# Altium schematic sheet PWR0.SchDoc(PWR0)
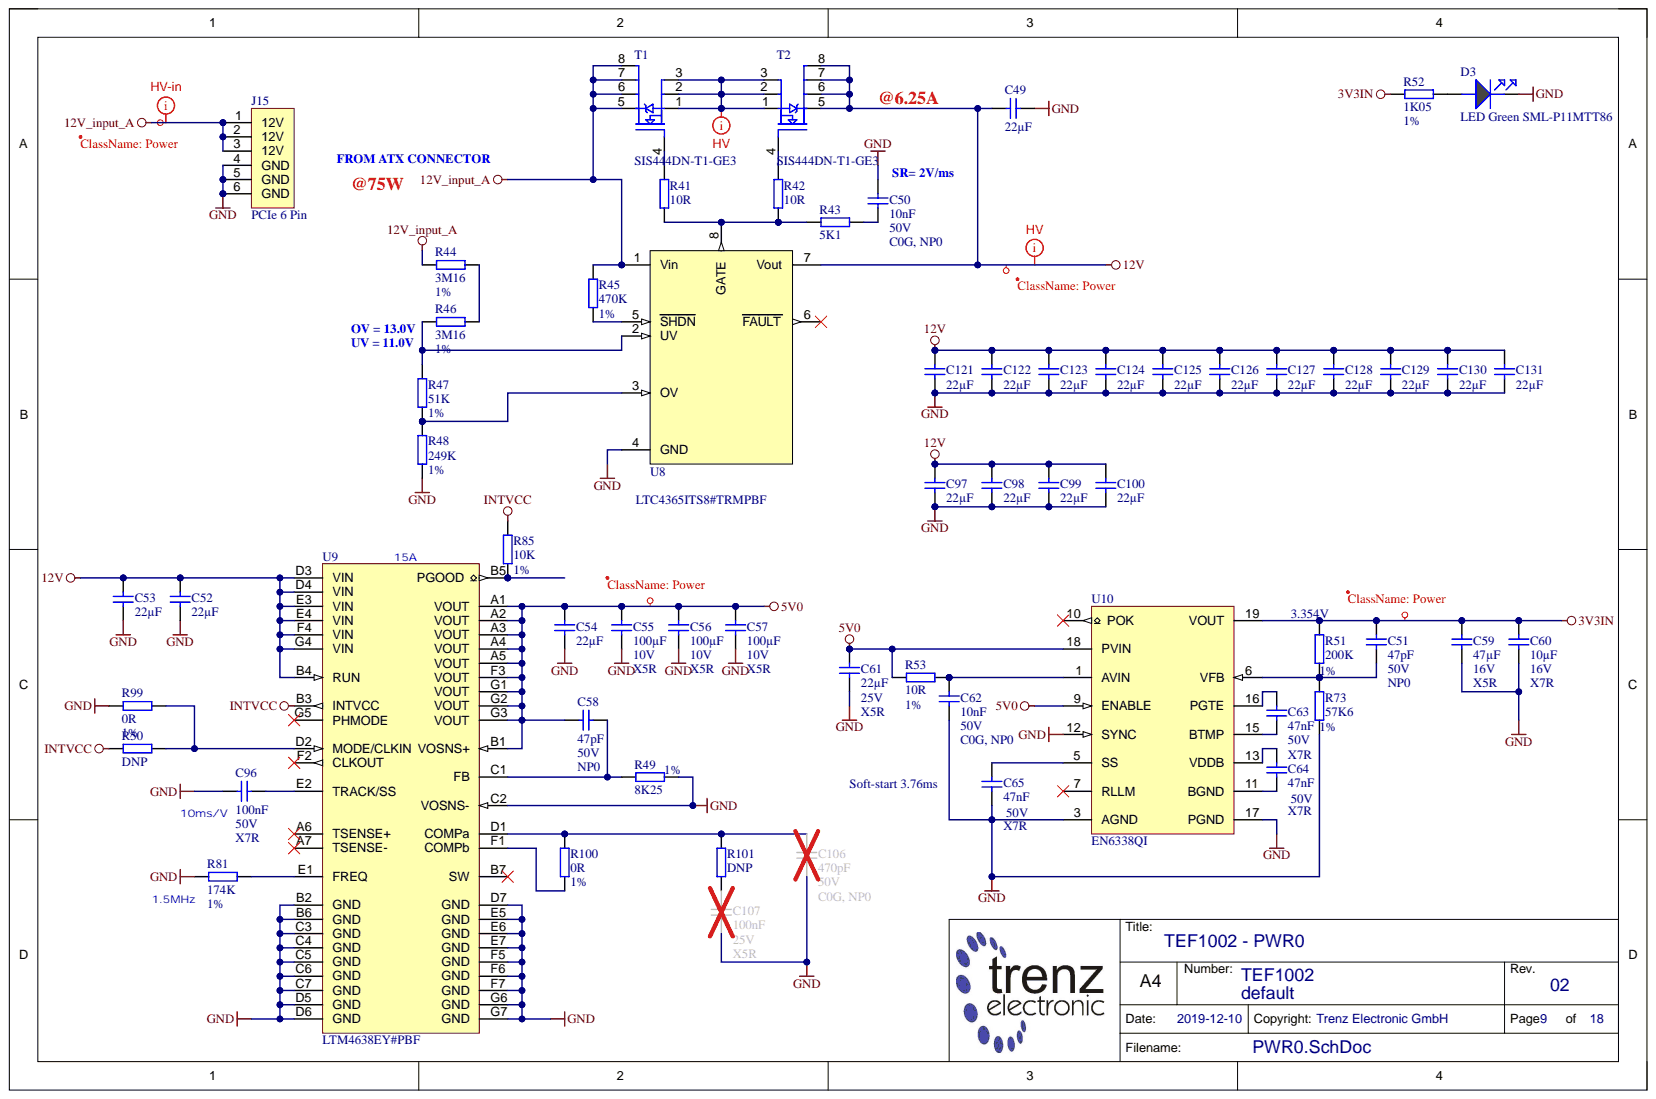
[diagram p0: PWR0.SchDoc]

## Parts
C49  22µF  [CAPC3216X180N]
C50  10nF  Voltage: 50V  [CAPC1608X90N]
C51  47pF  Technology: NP0  Voltage: 50V  [CAPC1005X55N]
C52  22µF  [CAPC3216X180N]
C53  22µF  [CAPC3216X180N]
C54  22µF  [CAPC3216X180N]
C55  100µF  Technology: X5R  Voltage: 10V  [CAPC3216X180N]
C56  100µF  Technology: X5R  Voltage: 10V  [CAPC3216X180N]
C57  100µF  Technology: X5R  Voltage: 10V  [CAPC3216X180N]
C58  47pF  Voltage: 50V  [CAPC1005X55N]
C59  47µF  Technology: X5R  Voltage: 16V  [CAPC3216X180N]
C60  10µF  Technology: X7R  Voltage: 16V  [CAPC2012X135N]
C61  22µF  Technology: X5R  Voltage: 25V  [CAPC3216X180N]
C62  10nF  Voltage: 50V  [CAPC1608X90N]
C63  47nF  Voltage: 50V  [CAPC1005X55N]
C64  47nF  Technology: X7R  Voltage: 50V  [CAPC1005X55N]
C65  47nF  Technology: X7R  Voltage: 50V  [CAPC1005X55N]
C96  100nF  Voltage: 50V  [CAPC1005X55N]
C97  22µF  [CAPC3216X180N]
C98  22µF  [CAPC3216X180N]
C99  22µF  [CAPC3216X180N]
C100  22µF  [CAPC3216X180N]
C106  470pF  Voltage: 50V  [CAPC1005X55N]
C107  100nF  Technology: X5R  Voltage: 25V  [CAPC1005X55N]
C121  22µF  [CAPC3216X180N]
C122  22µF  [CAPC3216X180N]
C123  22µF  [CAPC3216X180N]
C124  22µF  [CAPC3216X180N]
C125  22µF  [CAPC3216X180N]
C126  22µF  [CAPC3216X180N]
C127  22µF  [CAPC3216X180N]
C128  22µF  [CAPC3216X180N]
C129  22µF  [CAPC3216X180N]
C130  22µF  [CAPC3216X180N]
C131  22µF  [CAPC3216X180N]
D3  LED Green SML-P11MTT86  Database Table Name: LED  H-ART: SML-P11MTT86  [LEDC1006X25N_GREEN]
J15  PCIe 6 Pin  [45558-0003]
R41  10R  [RESC1005X40N]
R42  10R  [RESC1005X40N]
R43  5K1  [RESC1005X40N]
R44  3M16  [RESC1005X40N]
R45  470K  Tolerance: 1%  [RESC1005X40N]
R46  3M16  Tolerance: 1%  [RESC1005X40N]
R47  51K  Tolerance: 1%  [RESC1005X40N]
R48  249K  Tolerance: 1%  [RESC1005X40N]
R49  8K25  Tolerance: 1%  [RESC1005X40N]
R50  DNP  [RESC1005X40N]  (no BOM)
R51  200K  Tolerance: 1%  [RESC1005X40N]
R52  1K05  Tolerance: 1%  [RESC1005X40N]
R53  10R  Tolerance: 1%  [RESC1005X40N]
R73  57K6  Tolerance: 1%  [RESC1005X40N]
R81  174K  Tolerance: 1%  [RESC1005X40N]
R85  10K  Tolerance: 1%  [RESC1005X40N]
R99  0R  [RESC1005X40N]
R100  0R  Tolerance: 1%  [RESC1005X40N]
R101  DNP  [RESC1005X40N]  (no BOM)
T1  SIS444DN-T1-GE3  [Si7106]
T2  SIS444DN-T1-GE3  [Si7106]
U8  LTC4365ITS8#TRMPBF  [TSOT-23-8]
U9  LTM4638EY#PBF  [BGA49C80P7X7_625X625X536B50N]
U10  EN6338QI  [EN6338QI]

## Nets
3V3IN: C51.1 C59.1 C60.2 R51.1 R52.1 U10.19(VOUT)
5V0: C54.2 C55.1 C56.1 C57.1 C58.1 C61.1 R53.1 U9.A1(VOUT) U9.A2(VOUT) U9.A3(VOUT) U9.A4(VOUT) U9.A5(VOUT) U9.B1(VOSNS+) U9.F3(VOUT) U9.G1(VOUT) U9.G2(VOUT) U9.G3(VOUT) U10.9(ENABLE) U10.18(PVIN)
12V: C49.2 C52.2 C53.2 C97.2 C98.2 C99.2 C100.2 C121.2 C122.2 C123.2 C124.2 C125.2 C126.2 C127.2 C128.2 C129.2 C130.2 C131.2 T2.5 T2.6 T2.7 T2.8 U8.7(Vout) U9.B4(RUN) U9.D3(VIN) U9.D4(VIN) U9.E3(VIN) U9.E4(VIN) U9.F4(VIN) U9.G4(VIN)
12V_input_A: J15.1(12V) J15.2(12V) J15.3(12V) R44.1 R45.1 T1.5 T1.6 T1.7 T1.8 U8.1(Vin)
GND: C49.1 C50.1 C52.1 C53.1 C54.1 C55.2 C56.2 C57.2 C59.2 C60.1 C61.2 C62.2 C65.2 C96.2 C97.1 C98.1 C99.1 C100.1 C106.1 C107.2 C121.1 C122.1 C123.1 C124.1 C125.1 C126.1 C127.1 C128.1 C129.1 C130.1 C131.1 D3.K J15.4(GND) J15.5(GND) J15.6(GND) R48.2 R49.2 R73.2 R81.1 R99.1 U8.4(GND) U9.B2(GND) U9.B6(GND) U9.C2(VOSNS-) U9.C3(GND) U9.C4(GND) U9.C5(GND) U9.C6(GND) U9.C7(GND) U9.D5(GND) U9.D6(GND) U9.D7(GND) U9.E5(GND) U9.E6(GND) U9.E7(GND) U9.F5(GND) U9.F6(GND) U9.F7(GND) U9.G6(GND) U9.G7(GND) U10.3(AGND) U10.12(SYNC) U10.17(PGND)
INTVCC: R50.1 R85.2 U9.B3(INTVCC)
NetC50_2: C50.2 R43.2
NetC51_2: C51.2 R51.2 R73.1 U10.6(VFB)
NetC58_2: C58.2 R49.1 U9.C1(FB)
NetC62_1: C62.1 R53.2 U10.1(AVIN)
NetC63_1: C63.1 U10.16(PGTE)
NetC63_2: C63.2 U10.15(BTMP)
NetC64_1: C64.1 U10.13(VDDB)
NetC64_2: C64.2 U10.11(BGND)
NetC65_1: C65.1 U10.5(SS)
NetC96_1: C96.1 U9.E2(TRACK/SS)
NetC106_2: C106.2 R100.2 R101.2 U9.D1(COMPa)
NetC107_1: C107.1 R101.1
NetD3_A: D3.A R52.2
NetR41_1: R41.1 T1.4
NetR41_2: R41.2 R42.2 R43.1 U8.8(GATE)
NetR42_1: R42.1 T2.4
NetR44_2: R44.2 R46.2
NetR45_2: R45.2 U8.5(SHDN)
NetR46_1: R46.1 R47.1 U8.2(UV)
NetR47_2: R47.2 R48.1 U8.3(OV)
NetR50_2: R50.2 R99.2 U9.D2(MODE/CLKIN)
NetR81_2: R81.2 U9.E1(FREQ)
NetR85_1: R85.1 U9.B5(PGOOD)
NetR100_1: R100.1 U9.F1(COMPb)
NetT1_1: T1.1 T1.2 T1.3 T2.1 T2.2 T2.3

Not connected: U8 6(FAULT); U9 A6(TSENSE+) A7(TSENSE-) B7(SW) F2(CLKOUT) G5(PHMODE); U10 7(RLLM) 10(POK)

## Library data of the parts
C49 C52-C54 C61 C97-C100 C121-C131 = 24886: KON 22µF X5R 25V 10%  SMD BF 3216 (1206); Database Table Name: C_3216_1206; Mixed: SMT; Preferred: Yes; Temperature-Range: -55/+85; Value: 22u; AN: 24886; Case: 3216 (1206); Component Kind: Standard; Library Name: TE.DbLib; Tolerance: 10%
  C49 C52-C54 C97-C100 C121-C131: Technology: X5R; Voltage: 25V
C50 C62 = 26587: KON 10nF 50V NP0 SMD BF 1608 (0603); Database Table Name: C_1608_0603; H-ART: C1608C0G1H103J080AA; Mixed: SMT; Value: 10n; AN: 26587; Case: 1608 (0603); Component Kind: Standard; Library Name: TE.DbLib; Technology: C0G, NP0
C51 C58 = 24885: KON 47pF 50V NP0 SMD BF 1005 (0402); Database Table Name: C_1005_0402; Mixed: SMT; Preferred: Yes; Value: 47p; AN: 24885; Case: 1005 (0402); Component Kind: Standard; Library Name: TE.DbLib
  C58: Technology: NP0
C55-C57 = 26278: KON 100µF 10V X5R 20% SMD BF 3216 (1206); Database Table Name: C_3216_1206; Mixed: SMT; Preferred: Yes; Temperature-Range: -55/+85; Value: 100u; AN: 26278; Case: 3216 (1206); Component Kind: Standard; Library Name: TE.DbLib; Tolerance: 20%
C59 = 24955: KON 47µF 16V  X5R 20% SMD BF 3216 (1206); Database Table Name: C_3216_1206; Mixed: SMT; Preferred: Yes; Temperature-Range: -55/+85; Value: 47u; AN: 24955; Case: 3216 (1206); Component Kind: Standard; Library Name: TE.DbLib; Tolerance: 20%
C60 = 24380: KON 10µF 16V X7R 10% SMD BF 2012 (0805); Database Table Name: C_2012_0805; Mixed: SMT; Preferred: Yes; Temperature-Range: -55/+125; Value: 10u; AN: 24380; Case: 2012 (0805); Component Kind: Standard; Library Name: TE.DbLib; Tolerance: 10%
C63-C65 = 24684: KON 47nF 50V X7R 10% SMD BF 1005 (0402); Database Table Name: C_1005_0402; Mixed: SMT; Temperature-Range: -55/+125; Value: 47n; AN: 24684; Case: 1005 (0402); Component Kind: Standard; Library Name: TE.DbLib; Tolerance: 10%
  C63: Technology: X7R
C96 = 22651: KON 100nF 50V X7R ±10% SMD BF 1005 (0402); Database Table Name: C_1005_0402; Mixed: SMT; Temperature-Range: -55/+125; Value: 100n; AN: 22651; Case: 1005 (0402); Component Kind: Standard; Library Name: TE.DbLib; Technology: X7R; Tolerance: 10%
C106 = 28221: KON 470pF 50V NP0 ±5% SMD BF 1005 (0402); Database Table Name: C_1005_0402; Mixed: SMT; Value: 470p; AN: 28221; Case: 1005 (0402); Component Kind: Standard; Library Name: TE.DbLib; Technology: C0G, NP0; Tolerance: ±5%
C107 = 22472: KON 100nF 25V X5R ±10% SMD BF 1005 (0402); Database Table Name: C_1005_0402; Mixed: SMT; Preferred: Yes; Temperature-Range: -55/+85; Value: 100n; AN: 22472; Case: 1005 (0402); Component Kind: Standard; Library Name: TE.DbLib
D3 = 24211: LED Grün SML-P11MTT86 SMD; Mixed: SMT; Preferred: Yes; Temperature-Range: -40/+85; AN: 24211; Case: 1005 (0402); Component Kind: Standard; Library Name: TE.DbLib; HelpURL: B:\AD\adlib\datasheet\SML-P1
J15 = 24680: Mini-Fit JR Header PCIe 6 Pin Header 90°; Database Table Name: CON_PWR; Mixed: THT; Trenz-Stock: Yes; AN: 24680; Component Kind: Standard; H-ART: 45558-0003; Library Name: TE.DbLib; HelpURL: B:\AD\adlib\datasheet\45558-0003
R41 R42 R53 = 22844: WID SMD 10R 1% 0,063W  BF 1005 (0402); Database Table Name: R_1005_0402; H-ART: RC0402FR-0710RL; Mixed: SMT; Preferred: Yes; Value: 10; AN: 22844; Case: 1005 (0402); Component Kind: Standard; Library Name: TE.DbLib; Technology: TK100
  R41 R42: Tolerance: 1%
R43 = 23125: WID SMD 5K1 1% 0,063W BF 1005 (0402); Database Table Name: R_1005_0402; Mixed: SMT; Value: 5.1K; AN: 23125; Case: 1005 (0402); Component Kind: Standard; Library Name: TE.DbLib; Technology: TK100; Tolerance: 1%
R44 R46 = 27581: WID SMD 3M16 1% 0,063W BF 1005 (0402); Database Table Name: R_1005_0402; Mixed: SMT; Value: 3.16M; AN: 27581; Case: 1005 (0402); Component Kind: Standard; Library Name: TE.DbLib; Technology: TK100
  R44: Tolerance: 1%
R45 = 23847: WID SMD 470K 1% 0,063W  BF 1005 (0402); Database Table Name: R_1005_0402; Mixed: SMT; AN: 23847; Case: 1005 (0402); Component Kind: Standard; Library Name: TE.DbLib; Technology: TK100; Value: 470K
R47 = 24466: WID SMD 51K 1% 0,063W; Database Table Name: R_1005_0402; Mixed: SMT; AN: 24466; Case: 1005 (0402); Component Kind: Standard; Library Name: TE.DbLib; Technology: TK100; Value: 51K
R48 = 26068: WID SMD 249K 1% 0,063W  BF 1005 (0402); Database Table Name: R_1005_0402; Mixed: SMT; AN: 26068; Case: 1005 (0402); Component Kind: Standard; Library Name: TE.DbLib; Technology: TK100; Value: 249K
R49 = 29987: WID SMD 8K25 1%  0,063W  BF 1005 (0402); Database Table Name: R_1005_0402_P; Mixed: SMT; Value: 8.25K; Voltage: 0.063W; AN: 29987; Case: 1005 (0402); Component Kind: Standard; Library Name: TE.DbLib; Technology: TK100
R50 R101 = 29416: WID SMD BF 1005 (0402) DNP; Database Table Name: R_1005_0402; AN: 29416; Case: 1005 (0402); Library Name: TE.DbLib; Value: DNP
R51 = 23846: WID SMD 200K 1% 0,063W  BF 1005 (0402); Database Table Name: R_1005_0402; H-ART: RC0402FR-07200KL; Mixed: SMT; Preferred: Yes; AN: 23846; Case: 1005 (0402); Component Kind: Standard; Library Name: TE.DbLib; Technology: TK100; Value: 200K
R52 = 25956: WID SMD 1K05 1% 0,063W  BF 1005 (0402); Database Table Name: R_1005_0402; Mixed: SMT; Value: 1.05K; AN: 25956; Case: 1005 (0402); Component Kind: Standard; Library Name: TE.DbLib; Technology: TK100
R73 = 24958: WID SMD 57K6 1% 0,063W  BF 1005 (0402); Database Table Name: R_1005_0402; Mixed: SMT; Preferred: Yes; Value: 57.6K; AN: 24958; Case: 1005 (0402); Component Kind: Standard; Library Name: TE.DbLib; Technology: TK100
R81 = 29672: WID SMD 174K 1% 0,063W BF 1005 (0402); Database Table Name: R_1005_0402; Mixed: SMT; AN: 29672; Case: 1005 (0402); Component Kind: Standard; Library Name: TE.DbLib; Technology: TK100; Value: 174K
R85 = 22750: WID SMD 10K 1% 0,063W BF 1005 (0402); Database Table Name: R_1005_0402; H-ART: RC0402FR-0710KL; Mixed: SMT; Preferred: Yes; AN: 22750; Case: 1005 (0402); Component Kind: Standard; Library Name: TE.DbLib; Technology: TK100; Value: 10K
R99 = 22738: WID SMD 0R  BF 1005 (0402); Database Table Name: R_1005_0402; H-ART: RC0402JR-070RL; Mixed: SMT; Preferred: Yes; Tolerance: 1%; Value: 0; AN: 22738; Case: 1005 (0402); Component Kind: Standard; Library Name: TE.DbLib; Technology: TK100
R100 = 22738: WID SMD 0R  BF 1005 (0402); Database Table Name: R_1005_0402; H-ART: RC0402JR-070RL; Mixed: SMT; Preferred: Yes; Value: 0; AN: 22738; Case: 1005 (0402); Component Kind: Standard; Library Name: TE.DbLib; Technology: TK100
T1 T2 = 29102: Database Table Name: TRANSISTOR; Mixed: SMT; Temperature-Range: -55/+150 (Tj abs); Value: 30A; Voltage: 30V; AN: 29102; Case: PowerPAK 1212-8; Component Kind: Standard; Description: SIS444DN-T1-GE3; H-ART: SIS444DN-T1-GE3; Library Name: TE.DbLib; Technology: N-channel; HelpURL: B:\AD\adlib\datasheet\SiS444DN\
U8 = 25923: Database Table Name: SUPERVISOR; Mixed: SMT; Temperature-Range: -40/+85; Trenz-Stock: Yes; AN: 25923; Case: TS8; Component Kind: Standard; Description: LTC4365ITS8#TRMPBF; H-ART: LTC4365ITS8#TRMPBF; Library Name: TE.DbLib; Storage-Temperature: -65/+150(Abs); HelpURL: b:\AD\adlib\datasheet\LTC4365xTS8\
U9 = 29945: Database Table Name: DCDC; Mixed: SMT; Temperature-Range: 0/+125(Tj); AN: 29945; Case: BGA49; Component Kind: Standard; Description: LTM4638EY#PBF; H-ART: LTM4638EY#PBF; Library Name: TE.DbLib; Storage-Temperature: -55/+125(Abs); HelpURL: b:\AD\adlib\datasheet\LTM4638\
U10 = 29249: Database Table Name: DCDC; Mixed: SMT; Temperature-Range: -40/+125 (Tj); AN: 29249; Component Kind: Standard; Description: EN6338QI; H-ART: EN6338QI; Library Name: TE.DbLib; HelpURL: b:\AD\adlib\datasheet\EN6338QI\
